# Altium schematic sheet LoRa_Com.SchDoc(LoRa_Com)
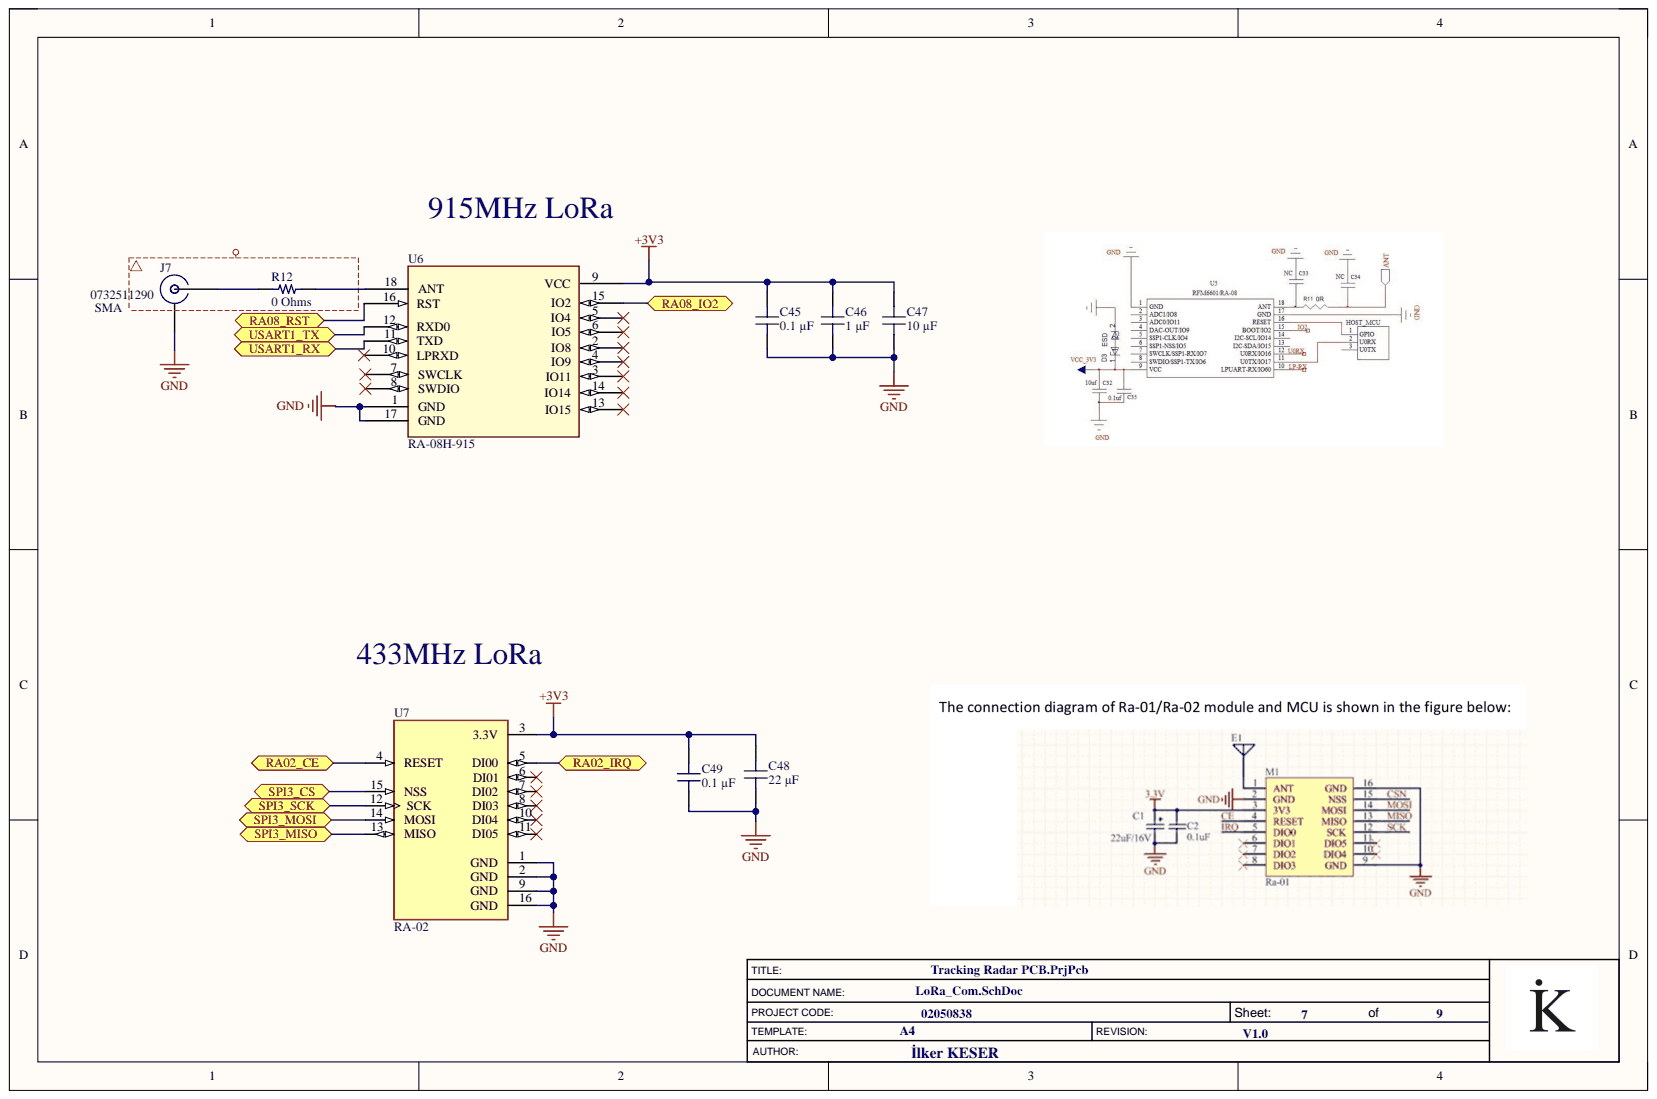
[diagram p0: LoRa_Com.SchDoc]

## Parts
C45  0.1 µF  [CAP 0402_1005]
C46  1 µF  [CAP 0402_1005]
C47  10 µF  [MURATA GRM188 0603_1608]
C48  22 µF  [MURATA GRM188 0603_1608]
C49  0.1 µF  [CAP 0603_1608]
J7  0732511290  [MOLEX SD-73251-129]
R12  0 Ohms  [RES 1206_3216]
U6  RA-08H-915  [RA-08H-915]
U7  RA-02  [RA-02]

## Ports
RA02_CE, RA02_IRQ, RA08_IO2, RA08_RST, SPI3_CS, SPI3_MISO, SPI3_MOSI, SPI3_SCK, USART1_RX, USART1_TX

## Nets
+3V3: C45.2 C46.2 C47.2 C48.2 C49.2 U6.9(VCC) U7.3(3.3V)
GND: C45.1 C46.1 C47.1 C48.1 C49.1 J7.G U6.1(GND) U6.17(GND) U7.1(GND) U7.2(GND) U7.9(GND) U7.16(GND)
RA02_CE: U7.4(RESET) ; port RA02_CE
RA02_IRQ: U7.5(DI00) ; port RA02_IRQ
RA08_IO2: U6.15(IO2) ; port RA08_IO2
RA08_RST: U6.16(RST) ; port RA08_RST
SPI3_CS: U7.15(NSS) ; port SPI3_CS
SPI3_MISO: U7.13(MISO) ; port SPI3_MISO
SPI3_MOSI: U7.14(MOSI) ; port SPI3_MOSI
SPI3_SCK: U7.12(SCK) ; port SPI3_SCK
USART1_RX: U6.11(TXD) ; port USART1_RX
USART1_TX: U6.12(RXD0) ; port USART1_TX
NetJ7_S: J7.S R12.1
NetR12_2: R12.2 U6.18(ANT)

Not connected: U6 2(IO8) 3(IO11) 4(IO9) 5(IO4) 6(IO5) 7(SWCLK) 8(SWDIO) 10(LPRXD) 13(IO15) 14(IO14); U7 6(DI01) 7(DI02) 8(DI03) 10(DI04) 11(DI05)

## Library data of the parts
C45 = C0402C104M9PAC7867: CAP CER 0.1UF 6.3V X5R 0402; manufacturer: KEMET; Database Table Name: Capacitors - Ceramic - 0402; Tolerance: ±20%; Applications: Bypass, Decoupling; Capacitance: 0.1 µF; Component Kind: Standard; componentlink1description: Datasheet; componentlink1url: https://api.kemet.com/component-edge/download/datasheet/C0402C104M9PACTU.pdf; componentlink2description: DigiKey Link; componentlink2url: https://www.digikey.com/en/products/detail/kemet/C0402C104M9PAC7867/2196244; Device Package: 0402 (1005 Metric); lastupdated: 9.02.2024 20:04:15; Library Name: Celestial Altium Library - altium_library.DbLib; Lifecycle Status: Active; Minimum Order: 1; Mounting Type: Surface Mount, MLCC; Operating Temperature: -55°C ~ 85°C; Package / Case: 0402 (1005 Metric); packaging: CutTape; Part Number: C0402C104M9PAC7867; Part Status: Active; partid: 33676; price: 0.01; series: SMD Comm X5R; Size / Dimension: 0.039" L x 0.020" W (1.00mm x 0.50mm); Supplier 1: DigiKey; Supplier Part Number 1: 399-C0402C104M9PAC7867CT-ND; Temperature Coefficient: X5R; Thickness (Max): 0.022" (0.55mm); value: 0.1 µF; Voltage - Rated: 6.3V
C46 = GRM152R60J105ME15D: CAP CER 1UF 6.3V X5R 0402; manufacturer: Murata Electronics; Database Table Name: Capacitors - Ceramic - 0402; Tolerance: ±20%; Applications: General Purpose; Capacitance: 1 µF; Component Kind: Standard; componentlink1description: Datasheet; componentlink1url: https://search.murata.co.jp/Ceramy/image/img/A01X/G101/ENG/GRM152R60J105ME15-01.pdf; componentlink2description: DigiKey Link; componentlink2url: https://www.digikey.com/en/products/detail/murata-electronics/GRM152R60J105ME15D/4904809; Device Package: 0402 (1005 Metric); lastupdated: 9.02.2024 20:04:33; Library Name: Celestial Altium Library - altium_library.DbLib; Lifecycle Status: Active; Minimum Order: 1; Mounting Type: Surface Mount, MLCC; Operating Temperature: -55°C ~ 85°C; Package / Case: 0402 (1005 Metric); packaging: TapeAndReel; Part Number: GRM152R60J105ME15D; Part Status: Active; partid: 4147; price: 0.03; series: GRM; Size / Dimension: 0.039" L x 0.020" W (1.00mm x 0.50mm); Supplier 1: DigiKey; Supplier Part Number 1: 490-10006-1-ND; Temperature Coefficient: X5R; Thickness (Max): 0.009" (0.22mm); value: 1 µF; Voltage - Rated: 6.3V
C47 = GRM188R61A106MAALD: CAP CER 10UF 10V X5R 0603; manufacturer: Murata Electronics; Database Table Name: Capacitors - Ceramic - 0603; Tolerance: ±20%; Applications: General Purpose; Capacitance: 10 µF; Component Kind: Standard; componentlink1description: Datasheet; componentlink1url: https://search.murata.co.jp/Ceramy/image/img/A01X/G101/ENG/GRM188R61A106MAAL-01.pdf; componentlink2description: DigiKey Link; componentlink2url: https://www.digikey.com/en/products/detail/murata-electronics/GRM188R61A106MAALD/4905335; Device Package: 0603 (1608 Metric); lastupdated: 20.07.2024 00:23:22; Library Name: Celestial Altium Library - altium_library.DbLib; Lifecycle Status: Active; Minimum Order: 1; Mounting Type: Surface Mount, MLCC; Operating Temperature: -55°C ~ 85°C; Package / Case: 0603 (1608 Metric); packaging: TapeAndReel; Part Number: GRM188R61A106MAALD; Part Status: Active; partid: 40505; price: 0.03; series: GRM; Size / Dimension: 0.063" L x 0.031" W (1.60mm x 0.80mm); Supplier 1: DigiKey; Supplier Part Number 1: 490-12734-1-ND; Temperature Coefficient: X5R; Thickness (Max): 0.037" (0.95mm); value: 10 µF; Voltage - Rated: 10V
C48 = GRM188C61C226ME01W: CAP CER 22UF 16V X5S 0603; manufacturer: Murata Electronics; Database Table Name: Capacitors - Ceramic - 0603; Tolerance: ±20%; Applications: General Purpose; Capacitance: 22 µF; Component Kind: Standard; componentlink1description: Datasheet; componentlink1url: https://search.murata.co.jp/Ceramy/image/img/A01X/G101/ENG/GRM188C61C226ME01-01A.pdf; componentlink2description: DigiKey Link; componentlink2url: https://www.digikey.com/en/products/detail/murata-electronics/GRM188C61C226ME01W/22528390; Device Package: 0603 (1608 Metric); lastupdated: 20.07.2024 00:23:18; Library Name: Celestial Altium Library - altium_library.DbLib; Lifecycle Status: Active; Minimum Order: 1; Mounting Type: Surface Mount, MLCC; Operating Temperature: -55°C ~ 85°C; Package / Case: 0603 (1608 Metric); packaging: TapeAndReel; Part Number: GRM188C61C226ME01W; partid: 60288; price: 0.09; series: GRM; Size / Dimension: 0.063" L x 0.031" W (1.60mm x 0.80mm); Supplier 1: DigiKey; Supplier Part Number 1: 490-GRM188C61C226ME01WCT-ND; Temperature Coefficient: X5S; Thickness (Max): 0.039" (1.00mm); value: 22 µF; Voltage - Rated: 16V
C49 = 885012206046: CAP CER 0.1UF 16V X7R 0603; manufacturer: Würth Elektronik; Database Table Name: Capacitors - Ceramic - 0603; Tolerance: ±10%; Applications: General Purpose; Capacitance: 0.1 µF; Component Kind: Standard; componentlink1description: Datasheet; componentlink1url: https://katalog.we-online.de/pbs/datasheet/885012206046.pdf; componentlink2description: DigiKey Link; componentlink2url: https://www.digikey.com/en/products/detail/würth-elektronik/885012206046/5453820; Device Package: 0603 (1608 Metric); lastupdated: 9.02.2024 20:10:12; Library Name: Celestial Altium Library - altium_library.DbLib; Lifecycle Status: Active; Minimum Order: 1; Mounting Type: Surface Mount, MLCC; Operating Temperature: -55°C ~ 125°C; Package / Case: 0603 (1608 Metric); packaging: TapeAndReel; Part Number: 885012206046; Part Status: Active; partid: 15291; price: 0.03; series: WCAP-CSGP; Size / Dimension: 0.063" L x 0.031" W (1.60mm x 0.80mm); Supplier 1: DigiKey; Supplier Part Number 1: 732-7965-1-ND; Temperature Coefficient: X7R; Thickness (Max): 0.034" (0.87mm); value: 0.1 µF; Voltage - Rated: 16V
J7 = 0732511290: CONN SMA JACK STR 50OHM EDGE MNT; Manufacturer: Molex; Connector Type: Jack, Female Socket; Contact Termination: Solder; Database Table Name: Connectors - Coaxial RF; Frequency - Max: 18 GHz; Number of Ports: 1; Component Kind: Standard; ComponentLink1Description: Datasheet; ComponentLink1URL: https://www.molex.com/pdm_docs/ps/PS-89675-346.pdf; ComponentLink2Description: DigiKey Link; ComponentLink2URL: https://www.digikey.com/en/products/detail/molex/0732511290/3878247; Connector Style: SMA; Fastening Type: Threaded; Housing Color: Gold; LastUpdated: 29.06.2022 18:07:55; Library Name: Celestial Altium Library - altium_library.DbLib; Lifecycle Status: Active; Minimum Order: 1; Mounting Feature: Bulkhead - Front Side Nut; Mounting Type: Board Edge, End Launch; Panel Mount; Packaging: Tray; Part Number: 0732511290; Part Status: Active; Price: 4.46; Series: 73251; Shield Termination: Solder; Supplier 1: DigiKey; Supplier Part Number 1: WM9461-ND; Impedance: 50Ohm
R12 = CRCW12060000Z0EB: RES SMD 0 OHM JUMPER 1/4W 1206; Manufacturer: Vishay Dale; Database Table Name: Resistors - Surface Mount - 1206; Power (Watts): 0.25W, 1/4W; Component Kind: Standard; ComponentLink1Description: Datasheet; ComponentLink1URL: http://www.vishay.com/docs/20035/dcrcwe3.pdf; ComponentLink2Description: DigiKey Link; ComponentLink2URL: https://www.digikey.com/en/products/detail/vishay-dale/CRCW12060000Z0EB/5076259; Composition: Thick Film; Device Package: 1206 (3216 Metric); Features: Automotive AEC-Q200; Height - Seated (Max): 0.024" (0.60mm); LastUpdated: 9.02.2024 20:54:45; Library Name: Celestial Altium Library - altium_library.DbLib; Lifecycle Status: Active; Minimum Order: 1; Number of Terminations: 2; Operating Temperature: -55°C ~ 155°C; Package / Case: 1206 (3216 Metric); Packaging: TapeAndReel; Part Number: CRCW12060000Z0EB; Part Status: Active; PartId: 26977; Price: 0.01; Ratings: AEC-Q200; Resistance: 0 Ohms; Series: CRCW; Size / Dimension: 0.126" L x 0.063" W (3.20mm x 1.60mm); Supplier 1: DigiKey; Supplier Device Package: 1206; Supplier Part Number 1: 541-3032-1-ND; Tolerance: Jumper; Value: 0 Ohms
U6 = RA-08H-915: RF TXRX MODULE 915MHZ LORAWAN; Manufacturer: Ai-Thinker; Component Kind: Standard; ComponentLink1Description: Datasheet; ComponentLink1URL: https://docs.ai-thinker.com/_media/lora/docs/ra-08h_v1.1.0_specification.pdf; ComponentLink2Description: Digikey Link; ComponentLink2URL: https://www.digikey.com/en/products/detail/ai-thinker/RA-08H-915/16707689; Library Name: PCB_Lib.IntLib
U7 = RA-02: General ISM < 1GHz LoRa™ Transceiver Module 410MHz ~ 525MHz Antenna Not Included, I-PEX Surface Mount; Manufacturer: Ai-Thinker; Current - Receiving: 12.15mA; Current - Transmitting: 93mA ~ 97mA; Data Rate: 300kbps; Modulation: FSK, GFSK, GMSK, MSK, OOK; Power - Output: 18dBm; Protocol: LoRa; Sensitivity: -141dBm; Serial Interfaces: SPI; Voltage - Supply: 2.5V ~ 3.7V; Antenna Type: Antenna Not Included, I-PEX; Component Kind: Standard; ComponentLink1Description: Product Specification; ComponentLink1URL: https://docs.ai-thinker.com/_media/lora/docs/c048ps01a1_ra-02_product_specification_v1.1.pdf; ComponentLink2Description: Digikey Link; ComponentLink2URL: https://www.digikey.com/en/products/detail/ai-thinker/RA-02/16688840; Frequency: 410MHz ~ 525MHz; Library Name: PCB_Lib.IntLib; Mounting Type: Surface Mount; Operating Temperature: -30°C ~ 85°C; Package / Case: 16-SMD Module; RF Family/Standard: General ISM < 1GHz
